# Altium schematic sheet OpenRing_Haptic.SchDoc
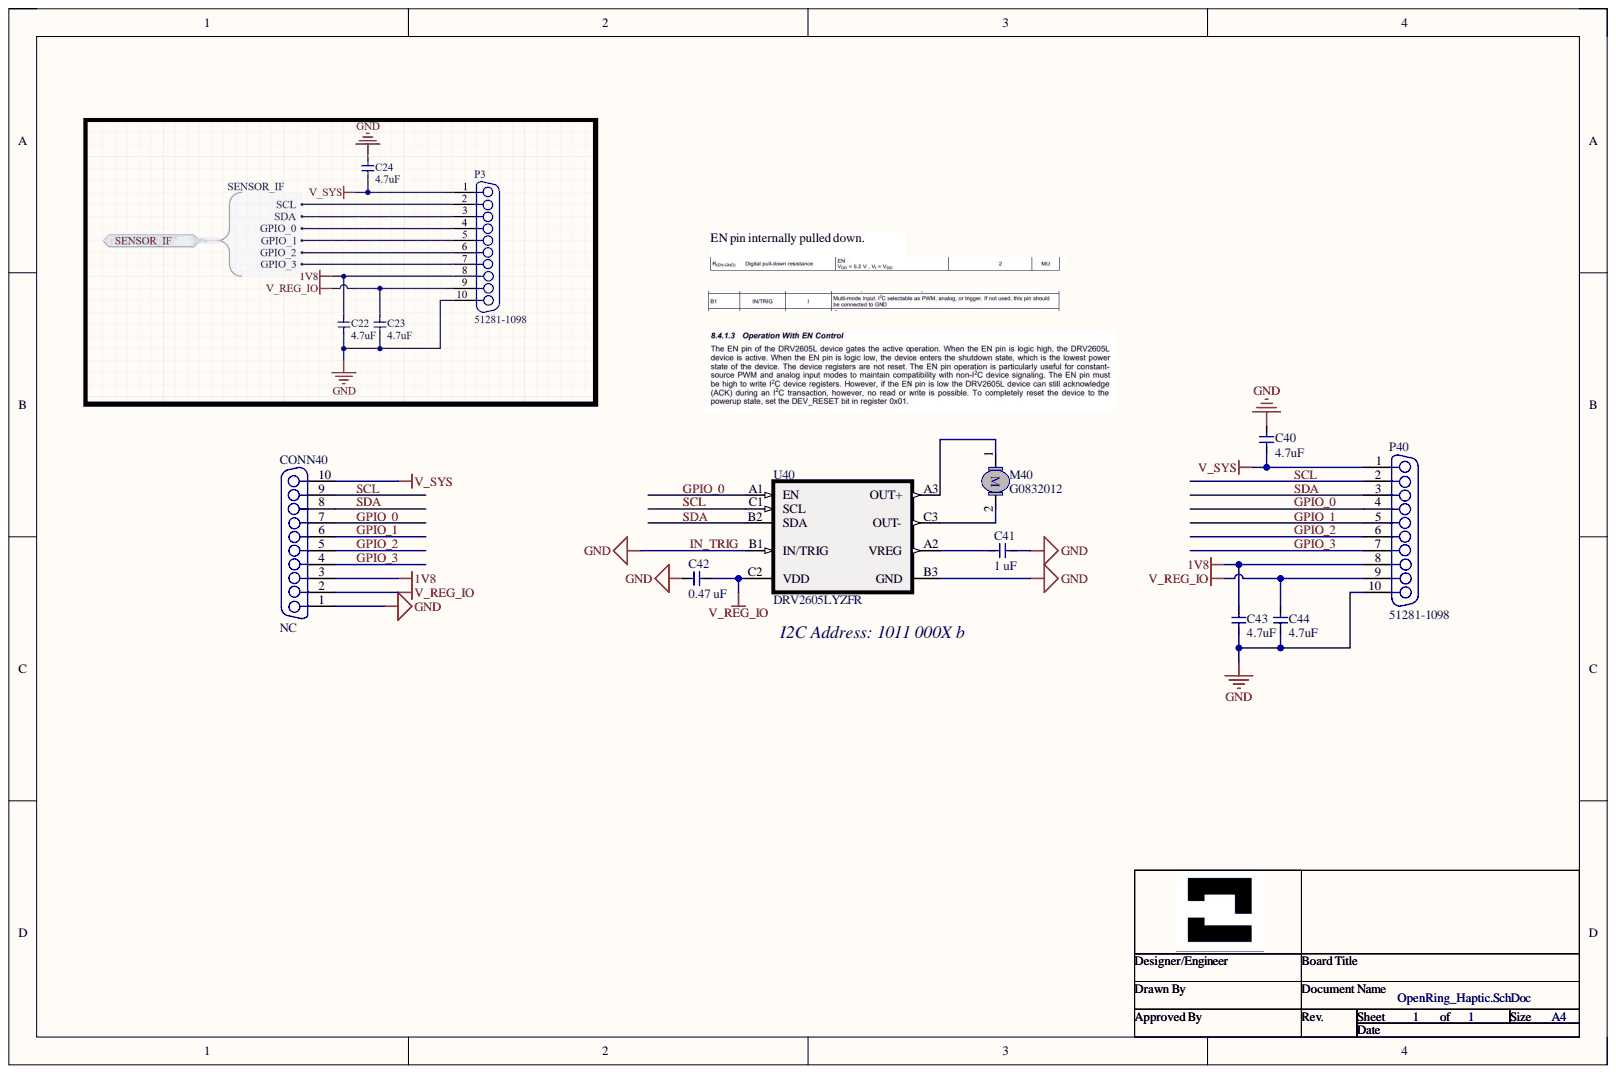
[diagram p0: OpenRing_Haptic.SchDoc]

## Parts
C40  4.7uF  [CAPC1005X05N]
C41  1 uF  [CAPC1005X05N]
C42  0.47 uF  [CAPC1005X05N]
C43  4.7uF  [CAPC1005X05N]
C44  4.7uF  [CAPC1005X05N]
CONN40  NC  [51281-1098-PCB]
M40  G0832012  [G0832012]
P40  51281-1098  [51281-1098]
U40  DRV2605LYZFR  [BGA9C50P3X3_144X144X62]

## Nets
1V8: C43.2 CONN40.3 P40.8
V_REG_IO: C42.1 C44.2 CONN40.2 P40.9 U40.C2(VDD)
V_SYS: C40.1 CONN40.10 P40.1
GND: C40.2 C41.1 C42.2 C43.1 C44.1 CONN40.1 P40.10 U40.B1(IN/TRIG) U40.B3(GND)
GPIO_0: CONN40.7 P40.4 U40.A1(EN)
GPIO_1: CONN40.6 P40.5
GPIO_2: CONN40.5 P40.6
GPIO_3: CONN40.4 P40.7
SCL: CONN40.9 P40.2 U40.C1(SCL)
SDA: CONN40.8 P40.3 U40.B2(SDA)
NetC41_2: C41.2 U40.A2(VREG)
NetM40_1: M40.1 U40.A3(OUT+)
NetM40_2: M40.2 U40.C3(OUT-)

## Library data of the parts
C40 C43 C44 = GRM155R61A475MEAAD: Multilayer Ceramic Capacitor, 4.7 uF, 10 V, ± 20%, X5R, 0402 [1005 Metric]; Manufacturer: Murata; Dielectric: 1537DielectricType; Material: 4241ConductorMaterial; Voltage Rating: 10V; Case Code (Imperial): 0402; Case Code (Metric): 1005; Case/Package: 0402; Category: Ceramic Capacitors; Component Kind: Standard; ComponentLink1Description: http://www.farnell.com/datasheets/2189109.pdf?src-supplier=Farnell; ComponentLink1URL: http://www.farnell.com/datasheets/2189109.pdf?src-supplier=Farnell; ComponentLink2Description: http://www.farnell.com/datasheets/2189109.pdf?src-supplier=Newark; ComponentLink2URL: http://www.farnell.com/datasheets/2189109.pdf?src-supplier=Newark; ComponentLink3Description: http://www.farnell.com/datasheets/2189109.pdf?src-supplier=Element14; ComponentLink3URL: http://www.farnell.com/datasheets/2189109.pdf?src-supplier=Element14; ComponentLink4Description: http://datasheet.octopart.com/GRM155R61A475MEAAD-Murata-datasheet-68589269.pdf?src-supplier=Distrelec; ComponentLink4URL: http://datasheet.octopart.com/GRM155R61A475MEAAD-Murata-datasheet-68589269.pdf?src-supplier=Distrelec; ComponentLink5Description: http://datasheet.octopart.com/GRM155R61A475MEAAD-Murata-datasheet-68589269.pdf?src-supplier=Farnell; ComponentLink5URL: http://datasheet.octopart.com/GRM155R61A475MEAAD-Murata-datasheet-68589269.pdf?src-supplier=Farnell; ComponentLink6Description: https://search.murata.co.jp/Ceramy/image/img/A01X/G101/ENG/GRM155R61A475MEAA-01.pdf?src-supplier=Digi-Key; ComponentLink6URL: https://search.murata.co.jp/Ceramy/image/img/A01X/G101/ENG/GRM155R61A475MEAA-01.pdf?src-supplier=Digi-Key; ComponentLink7Description: http://datasheet.octopart.com/GRM155R61A475MEAAD-Murata-datasheet-68589269.pdf?src-supplier=Future+Electronics; ComponentLink7URL: http://datasheet.octopart.com/GRM155R61A475MEAAD-Murata-datasheet-68589269.pdf?src-supplier=Future+Electronics; ComponentLink8Description: https://datasheet.octopart.com/GRM155R61A475MEAAD-Murata-datasheet-68589269.pdf?src-supplier=Newark; ComponentLink8URL: https://datasheet.octopart.com/GRM155R61A475MEAAD-Murata-datasheet-68589269.pdf?src-supplier=Newark; ComponentLink9Description: http://datasheet.octopart.com/GRM155R61A475MEAAD-Murata-datasheet-68589269.pdf?src-supplier=Verical; ComponentLink9URL: http://datasheet.octopart.com/GRM155R61A475MEAAD-Murata-datasheet-68589269.pdf?src-supplier=Verical; ComponentLink10Description: https://datasheet.octopart.com/GRM155R61A475MEAAD-Murata-datasheet-165579666.pdf?src-supplier=TME; ComponentLink10URL: https://datasheet.octopart.com/GRM155R61A475MEAAD-Murata-datasheet-165579666.pdf?src-supplier=TME; ComponentLink11Description: http://datasheet.octopart.com/GRM155R61A475MEAAD-Murata-datasheet-68589269.pdf?src-supplier=Quest; ComponentLink11URL: http://datasheet.octopart.com/GRM155R61A475MEAAD-Murata-datasheet-68589269.pdf?src-supplier=Quest; ComponentLink12Description: http://datasheet.octopart.com/GRM155R61A475MEAAD-Murata-datasheet-68589269.pdf?src-supplier=TTI; ComponentLink12URL: http://datasheet.octopart.com/GRM155R61A475MEAAD-Murata-datasheet-68589269.pdf?src-supplier=TTI; ComponentLink13Description: http://datasheet.octopart.com/GRM155R61A475MEAAD-Murata-datasheet-68589269.pdf?src-supplier=NAC+Semi; ComponentLink13URL: http://datasheet.octopart.com/GRM155R61A475MEAAD-Murata-datasheet-68589269.pdf?src-supplier=NAC+Semi; Height: 650um; Length: 1mm; Manufacturer Part Number: GRM155R61A475MEAAD; Max Operating Temperature: 85°C; Min Operating Temperature: -55°C; Mounting Technology: Surface Mount; Packaging: Tape and Reel; Pricing: 10000=0,0187, 50000=0,0159, 100000=0,0132 (USD); RoHS: Yes; RoHS Compliant: Yes; Series: GRM; Stock: 930000; Supplier 1: Mouser; Supplier 2: Farnell; Supplier Part Number 1: 81-GRM155R61A475MEAD; Supplier Part Number 2: 3581674; Thickness: 500um; Tolerance: 20%; Value: 4.7uF; Voltage Rating (DC): 10V; Width: 500um; Library Name: OpenRingComponents.IntLib
C41 = GRM155R61A105KE15D: CAP CER 1UF 10V X5R 0402; Manufacturer: Murata Electronics North America; Capacitance: 1µF; Tolerance: ±10%; Applications: General Purpose; Category: Capacitors; Component Kind: Standard; ComponentLink1Description: http://www.murata.com/~/media/webrenewal/support/library/catalog/products/capacitor/mlcc/c02e.ashx; ComponentLink1URL: http://www.murata.com/~/media/webrenewal/support/library/catalog/products/capacitor/mlcc/c02e.ashx; Features: -; Height - Seated (Max): -; Lead Spacing: -; Lead Style: -; Manufacturer Part Number: GRM155R61A105KE15D; Mounting Type: Surface Mount, MLCC; Operating Temperature: -55°C ~ 85°C; Package / Case: 0402 (1005 Metric); Packaging: Digi-Reel®; Part Status: Active; Pricing 1: 1=0.1, 10=0.024, 50=0.013, 100=0.011, 250=0.009, 500=0.0077, 1000=0.006, 5000=0.005 (USD); Ratings: -; RoHS: RoHS Compliant; Signal Integrity: Model Name; Size / Dimension: 0.039" L x 0.020" W (1.00mm x 0.50mm); Stock 1: 5520111; Supplier 1: Digi-Key; Supplier Part Number 1: 490-3890-6-ND; Temperature Coefficient: X5R; Thickness (Max): 0.022" (0.55mm); Value: 1 uF; Voltage - Rated: 10V; Library Name: OpenRingComponents.IntLib
C42 = GRM155R61A474KE15D: CAP CER 0.47UF 10V X5R 0402; Manufacturer: Murata Electronics North America; Capacitance: 0.47µF; Tolerance: ±10%; Applications: General Purpose; Category: Capacitors; Component Kind: Standard; ComponentLink1Description: http://www.murata.com/~/media/webrenewal/support/library/catalog/products/capacitor/mlcc/c02e.pdf; ComponentLink1URL: http://www.murata.com/~/media/webrenewal/support/library/catalog/products/capacitor/mlcc/c02e.pdf; Features: -; Height - Seated (Max): -; Lead Spacing: -; Lead Style: -; Manufacturer Part Number: GRM155R61A474KE15D; Mounting Type: Surface Mount, MLCC; Operating Temperature: -55°C ~ 85°C; Package / Case: 0402 (1005 Metric); Packaging: Digi-Reel®; Part Status: Active; Pricing 1: 1=0.08, 10=0.054, 50=0.029, 100=0.0246, 250=0.02008, 500=0.01718, 1000=0.01339, 5000=0.01116 (GBP); Ratings: -; RoHS: RoHS Compliant; Signal Integrity: Model Name; Size / Dimension: 0.039" L x 0.020" W (1.00mm x 0.50mm); Stock 1: 715573; Supplier 1: Digi-Key; Supplier Part Number 1: 490-3264-6-ND; Temperature Coefficient: X5R; Thickness (Max): 0.022" (0.55mm); Value: 0.47 uF; Voltage - Rated: 10V; Library Name: OpenRingComponents.IntLib
CONN40 = 51281-1098-PCB: System: Connector; Component Kind: Standard; Library Name: Connectors.SchLib
M40 = G0832012: VIBRATION MOTOR 1.8V; Manufacturer: Jinlong Machinery & Electronics, Inc.; Function: Vibration, LRA; Voltage - Rated: 1.8VAC; Approvals: -; Category: Motors, Solenoids, Driver Boards/Modules; Component Kind: Standard; ComponentLink1Description: http://www.vibration-motor.com/products/download/G0832012.pdf; ComponentLink1URL: http://www.vibration-motor.com/products/download/G0832012.pdf; Diameter - Shaft: -; Encoder Type: -; Features: -; Gear Reduction Ratio: -; Length - Shaft and Bearing: -; Manufacturer Part Number: G0832012; Motor Type: -; Mounting Hole Spacing: -; Operating Temperature: -20°C ~ 60°C; Part Status: Active; Power - Rated: -; Pricing: 1=3.17, 5=3.002, 10=2.918, 25=2.8348, 50=2.5846, 100=2.3345, 250=2.25112, 500=2.21778, 1000=2.08438 (USD); RoHS: RoHS Compliant; RPM: -; Size / Dimension: Round - 0.315" Dia (8.00mm); Stock: 810; Supplier 1: Digi-Key; Supplier Part Number 1: 1670-1034-ND; Termination Style: Wire Leads; Torque - Max Momentary (oz-in / mNm): -; Torque - Rated (oz-in / mNm): -; Type: AC Motor; Weight: -; Library Name: OpenRingComponents.IntLib
P40 = 51281-1098: FFC/FPC Connector, Surface Mount, Right-Angle, Non-ZIF, Dual Contact Style; Manufacturer: Molex; Component Kind: Standard; ComponentLink1Description: https://datasheet.octopart.com/51281-1098-Molex-datasheet-165827616.pdf?src-supplier=Farnell; ComponentLink1URL: https://datasheet.octopart.com/51281-1098-Molex-datasheet-165827616.pdf?src-supplier=Farnell; Library Name: Connectors.SchLib; Manufacturer Part Number: 51281-1098; Pricing: 1=0.7, 10=0.594, 25=0.5568, 50=0.53, 100=0.5047, 250=0.47304, 500=0.45042, 1000=0.42891 (USD); RoHS: No; Stock: 2876; Supplier: Digikey; Supplier 1: Mouser; Supplier 2: Digikey; Supplier 3: Digikey; Supplier Part Number: 900-0512811098CT-ND; Supplier Part Number 1: 538-51281-1098; Supplier Part Number 2: 900-0512811098CT-ND; Supplier Part Number 3: 900-0512811098DKR-ND; System: Connector
U40 = DRV2605LYZFR: Haptic driver for ERM/LRA with 2-V operation, waveform library & auto-resonance tracking 9-DSBGA -40 to 85; Manufacturer: Texas Instruments; Category: Integrated Circuits (ICs); Component Kind: Standard; ComponentLink1Description: http://www.ti.com/general/docs/suppproductinfo.tsp?distId=10&amp;gotoUrl=http%3A%2F%2Fwww.ti.com%2Flit%2Fgpn%2Fdrv2605l&src-supplier=Digi-Key; ComponentLink1URL: http://www.ti.com/general/docs/suppproductinfo.tsp?distId=10&amp;gotoUrl=http%3A%2F%2Fwww.ti.com%2Flit%2Fgpn%2Fdrv2605l&src-supplier=Digi-Key; ComponentLink2Description: http://www.ti.com/lit/pdf/ssiy004?src-supplier=Digi-Key; ComponentLink2URL: http://www.ti.com/lit/pdf/ssiy004?src-supplier=Digi-Key; ComponentLink3Description: http://www.ti.com/general/docs/lit/getliterature.tsp?genericPartNumber=DRV2605L&&fileType=pdf&src-supplier=Avnet; ComponentLink3URL: http://www.ti.com/general/docs/lit/getliterature.tsp?genericPartNumber=DRV2605L&&fileType=pdf&src-supplier=Avnet; Manufacturer Part Number: DRV2605LYZFR; Pricing: 1=1,93, 10=1,74, 50=1,74, 100=1,4, 1000=0,955, 10000=0,845 (USD); RoHS: Yes; Stock: 2778; Supplier: Mouser; Supplier 1: Mouser; Supplier Part Number: 595-DRV2605LYZFR; Supplier Part Number 1: 595-DRV2605LYZFR; Library Name: OpenRingComponents.IntLib
